# Altium schematic sheet PS_Controller_SCH.SchDoc(PS_Controller_SCH)
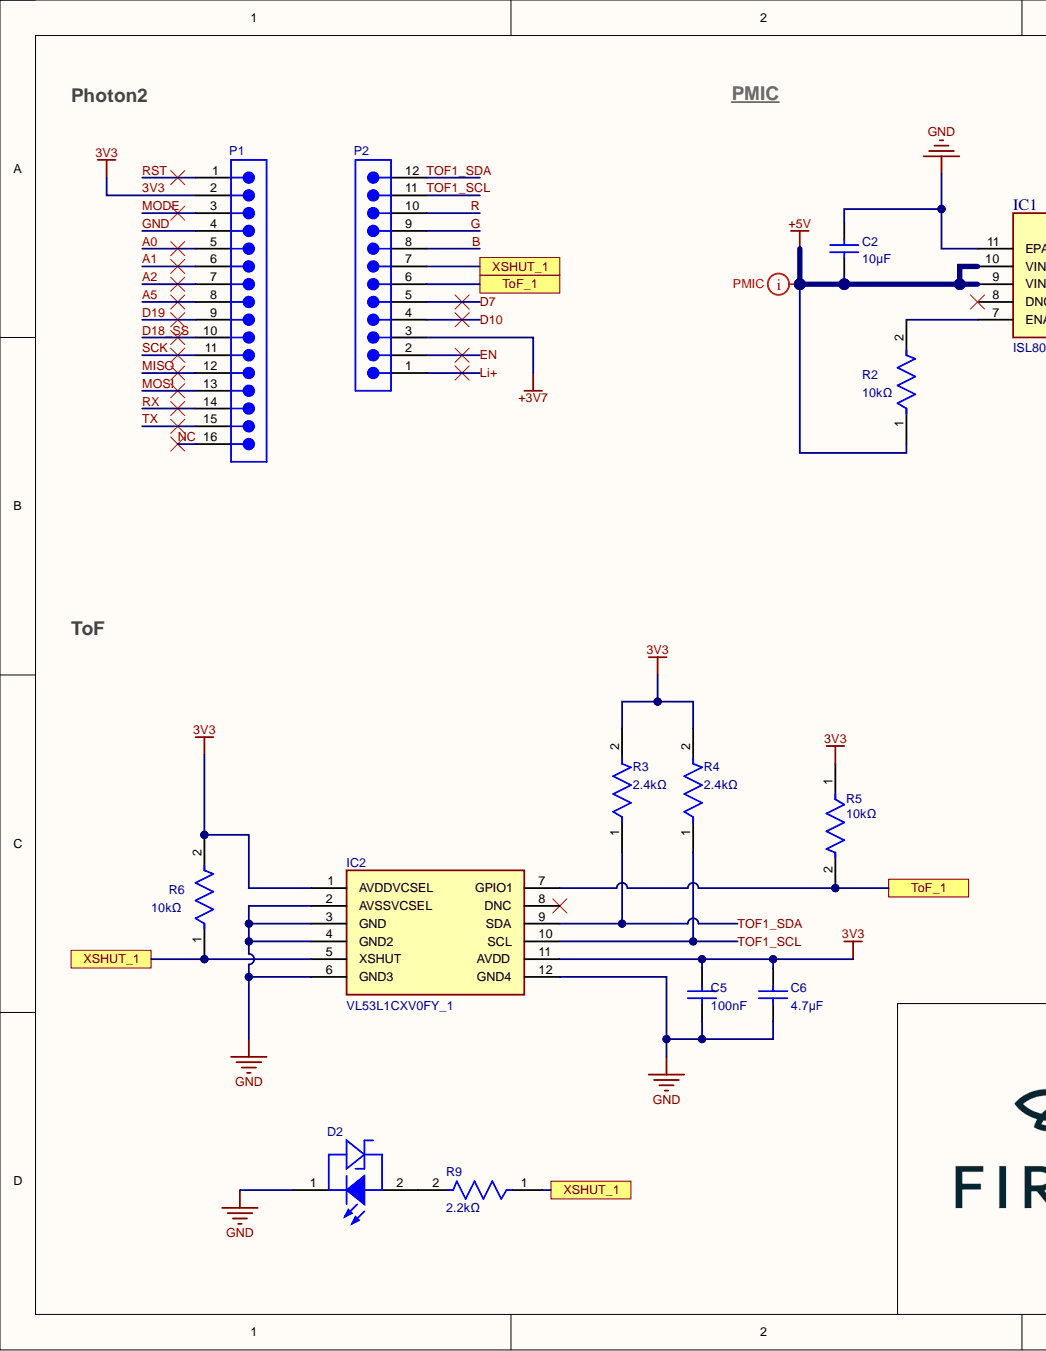
[diagram p0: PS_Controller_SCH.SchDoc, left]
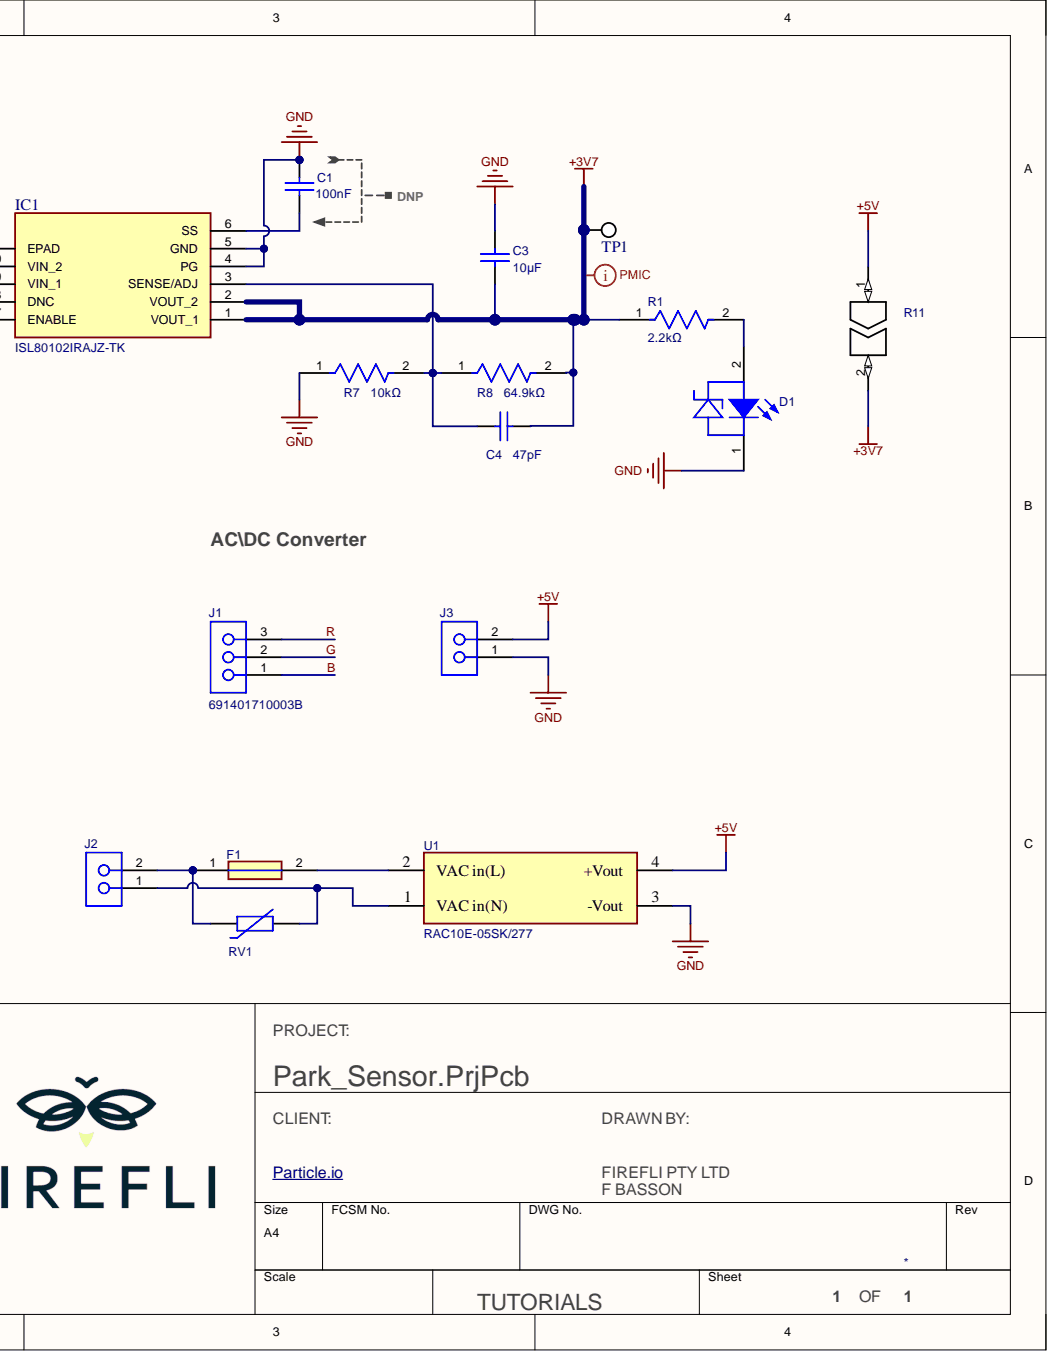
[diagram p1: PS_Controller_SCH.SchDoc, right]

## Parts
C1  Capacitance: 100nF  [WCAP-CSGP_0805_L2W1.25H0.8_Reflow]  (hidden comment: 885012207072)
C2  Capacitance: 10µF  [WCAP-CSGP_0805_L2W1.25H1.25]  (hidden comment: 885012107014)
C3  Capacitance: 10µF  [WCAP-CSGP_0805_L2W1.25H1.25]  (hidden comment: 885012107014)
C4  Capacitance: 47pF  [WCAP-CSGP_0603_L1.6W0.8H0.8_Reflow]  (hidden comment: 885012006021)
C5  Capacitance: 100nF  [WCAP-CSGP_0603_L1.6W0.8H0.8_Reflow]  (hidden comment: 885012206071)
C6  Capacitance: 4.7µF  [WCAP-CSGP_0603_L1.6W0.8H0.8_Reflow]  (hidden comment: 885012106012)
D1  150060BS73220  [WL-SMCC_0603_GS_BS]  (value not drawn)
D2  150060BS73220  [WL-SMCC_0603_GS_BS]  (value not drawn)
F1  SF-3812TM200T-2  [FUSC10131X330N]  (value not drawn)
IC1  ISL80102IRAJZ-TK  [ISL80101IRAJZ]
IC2  VL53L1CXV0FY_1  [VL53L1CXV0FY1]
J1  691401710003B  [691401710003B]
J2  691401710002B  [691401710002B]  (value not drawn)
J3  691401710002B  [691401710002B]  (value not drawn)
P1  61301611121  [61301611121]  (value not drawn)
P2  61301211121  [61301211121]  (value not drawn)
R1  value 2.2kΩ  [FP-RK73H1J-IPC_C]  (hidden comment: RK73H1JTTDD2201F)
R2  value 10kΩ  [FP-RK73H1J-IPC_C]  (hidden comment: RK73H1JTTD1002D)
R3  value 2.4kΩ  [FP-RK73H1J-IPC_C]  (hidden comment: RK73H1JTTD2401D)
R4  value 2.4kΩ  [FP-RK73H1J-IPC_C]  (hidden comment: RK73H1JTTD2401D)
R5  value 10kΩ  [FP-RK73H1J-IPC_C]  (hidden comment: RK73H1JTTD1002D)
R6  value 10kΩ  [FP-RK73H1J-IPC_C]  (hidden comment: RK73H1JTTD1002D)
R7  value 10kΩ  [FP-RK73H1J-IPC_C]  (hidden comment: RK73H1JTTD1002D)
R8  value 64.9kΩ  [FP-RK73H1J-IPC_C]  (hidden comment: RK73H1JTTDD6492F)
R9  value 2.2kΩ  [FP-RK73H1J-IPC_C]  (hidden comment: RK73H1JTTDD2201F)
R11  SolderBride_0.2  [RESC0000X00N]  (value not drawn)
RV1  820412711  [D12.5W6.5H16P1.3]  (value not drawn)
TP1  RCUCTE  [KSTN-5019_V]  (value not drawn)
U1  RAC10E-05SK/277  [FP-RAC10E-K-277-MFG]

## Ports
ToF_1, XSHUT_1

## Nets
+5V: C2.1 IC1.9(VIN_1) IC1.10(VIN_2) J3.2 R2.1 R11.1 U1.4(+Vout)
3V3: C3.1 C4.2 C5.2 C6.2 IC1.1(VOUT_1) IC1.2(VOUT_2) IC2.1(AVDDVCSEL) IC2.11(AVDD) P1.2 P2.3 R1.1 R3.2 R4.2 R5.1 R6.2 R8.2 R11.2 TP1.1
A0: P1.5
A1: P1.6
A2: P1.7
A5: P1.8
B: J1.1 P2.8
D7: P2.5
D10: P2.4
D18_SS: P1.10
D19: P1.9
EN: P2.2
G: J1.2 P2.9
GND: C1.2 C2.2 C3.2 C5.1 C6.1 D1.1 D2.1 IC1.4(PG) IC1.5(GND) IC1.11(EPAD) IC2.2(AVSSVCSEL) IC2.3(GND) IC2.4(GND2) IC2.6(GND3) IC2.12(GND4) J3.1 P1.4 R7.1 U1.3(-Vout)
Li+: P2.1
MISO: P1.12
MODE: P1.3
MOSI: P1.13
NC: P1.16
R: J1.3 P2.10
RST: P1.1
RX: P1.14
SCK: P1.11
TOF1_SCL: IC2.10(SCL) P2.11 R4.1
TOF1_SDA: IC2.9(SDA) P2.12 R3.1
ToF_1: IC2.7(GPIO1) P2.6 R5.2 ; port ToF_1
TX: P1.15
XSHUT_1: IC2.5(XSHUT) P2.7 R6.1 R9.1 ; port XSHUT_1
NetC1_1: C1.1 IC1.6(SS)
NetC4_1: C4.1 IC1.3(SENSE/ADJ) R7.2 R8.1
NetD1_2: D1.2 R1.2
NetD2_2: D2.2 R9.2
NetF1_1: F1.1 J2.2 RV1.1
NetF1_2: F1.2 U1.2(VAC_in(L))
NetIC1_7: IC1.7(ENABLE) R2.2
NetJ2_1: J2.1 RV1.2 U1.1(VAC_in(N))

Not connected: IC1 8(DNC); IC2 8(DNC)

## Library data of the parts
C1 = CMP-046-000743-3: WCAP-CSGP Multilayer Ceramic Chip Capacitor, General Purpose, size 0805, X7R, 100nF, 25VDC; Manufacturer: Wurth Elektronik; Capacitance Tolerance: +/-10%; Case/Size Code: 0805; Dissipation Factor: 3.5%; Insulation Resistance: 5GO; Rated Voltage (DC): 25V; Technical Reference: X7R0805104K025DFCT10000; Category: Multilayer Ceramic Chip Capacitors; Ceramic Type: X7R; Component Kind: Standard; Component Type: Standard; ComponentLink1Description: Website Link; ComponentLink1URL: https://www.we-online.com/redexpert/article/885012207072?ad; ComponentLink2Description: Datasheet Link; ComponentLink2URL: https://www.we-online.com/redexpert/spec/885012207072?ad; Design Item ID: 885012207072; Height: 0.8mm; Length: 2mm; Manufacturer Part Number: 885012207072; Match Code: WCAP-CSGP; Mount: Surface Mount; Operating Temperature Max: 125°C; Operating Temperature Min: -55°C; Packaging: Tape and Reel; Pin Count: 2; Source: WCAP-CSGP.SchLib; Width: 1.25mm; Library Name: Friedl_Basson
C2 C3 = CMP-046-000436-4: WCAP-CSGP Multilayer Ceramic Chip Capacitor, General Purpose, size 0805, X5R, 10µF, 16VDC; Manufacturer: Wurth Elektronik; Capacitance Tolerance: +/-20%; Case/Size Code: 0805; Dissipation Factor: 10%; Insulation Resistance: 0.01GO; Rated Voltage (DC): 16V; Technical Reference: X5R0805106M016DFCT10000; Category: Multilayer Ceramic Chip Capacitors; Ceramic Type: X5R; Component Kind: Standard; Component Type: Standard; ComponentLink1Description: Website Link; ComponentLink1URL: https://www.we-online.com/redexpert/article/885012107014?ad; ComponentLink2Description: Datasheet Link; ComponentLink2URL: https://www.we-online.com/redexpert/spec/885012107014?ad; Design Item ID: 885012107014; Height: 1.25mm; Length: 2mm; Manufacturer Part Number: 885012107014; Match Code: WCAP-CSGP; Mount: Surface Mount; Operating Temperature Max: 85°C; Operating Temperature Min: -55°C; Packaging: Tape and Reel; Pin Count: 2; Source: WCAP-CSGP.SchLib; Width: 1.25mm; Library Name: Friedl_Basson
C4 = CMP-046-000106-4: WCAP-CSGP Multilayer Ceramic Chip Capacitor, General Purpose, size 0603, NP0, 47pF, 16VDC; Manufacturer: Wurth Elektronik; Capacitance Tolerance: +/-5%; Case/Size Code: 0603; Insulation Resistance: 10GO; Q Factor: 1000; Rated Voltage (DC): 16V; Technical Reference: NP00603470J016DFCT10000; Category: Multilayer Ceramic Chip Capacitors; Ceramic Type: NP0; Component Kind: Standard; Component Type: Standard; ComponentLink1Description: Website Link; ComponentLink1URL: https://www.we-online.com/redexpert/article/885012006021?ad; ComponentLink2Description: Datasheet Link; ComponentLink2URL: https://www.we-online.com/redexpert/spec/885012006021?ad; Design Item ID: 885012006021; Height: 0.8mm; Length: 1.6mm; Manufacturer Part Number: 885012006021; Match Code: WCAP-CSGP; Mount: Surface Mount; Operating Temperature Max: 125°C; Operating Temperature Min: -55°C; Packaging: Tape and Reel; Pin Count: 2; Source: WCAP-CSGP.SchLib; Width: 0.8mm; Library Name: Friedl_Basson
C5 = CMP-046-000626-4: WCAP-CSGP Multilayer Ceramic Chip Capacitor, General Purpose, size 0603, X7R, 100nF, 25VDC; Manufacturer: Wurth Elektronik; Capacitance Tolerance: +/-10%; Case/Size Code: 0603; Dissipation Factor: 3.5%; Insulation Resistance: 5GO; Rated Voltage (DC): 25V; Technical Reference: X7R0603104K025DFCT10000; Category: Multilayer Ceramic Chip Capacitors; Ceramic Type: X7R; Component Kind: Standard; Component Type: Standard; ComponentLink1Description: Website Link; ComponentLink1URL: https://www.we-online.com/redexpert/article/885012206071?ad; ComponentLink2Description: Datasheet Link; ComponentLink2URL: https://www.we-online.com/redexpert/spec/885012206071?ad; Design Item ID: 885012206071; Height: 0.8mm; Length: 1.6mm; Manufacturer Part Number: 885012206071; Match Code: WCAP-CSGP; Mount: Surface Mount; Operating Temperature Max: 125°C; Operating Temperature Min: -55°C; Packaging: Tape and Reel; Pin Count: 2; Source: WCAP-CSGP.SchLib; Width: 0.8mm; Library Name: Friedl_Basson
C6 = CMP-046-000409-4: WCAP-CSGP Multilayer Ceramic Chip Capacitor, General Purpose, size 0603, X5R, 4.7µF, 10VDC; Manufacturer: Wurth Elektronik; Capacitance Tolerance: +/-20%; Case/Size Code: 0603; Dissipation Factor: 10%; Insulation Resistance: 0.02GO; Rated Voltage (DC): 10V; Technical Reference: X5R0603475M010DFCT10000; Category: Multilayer Ceramic Chip Capacitors; Ceramic Type: X5R; Component Kind: Standard; Component Type: Standard; ComponentLink1Description: Website Link; ComponentLink1URL: https://www.we-online.com/redexpert/article/885012106012?ad; ComponentLink2Description: Datasheet Link; ComponentLink2URL: https://www.we-online.com/redexpert/spec/885012106012?ad; Design Item ID: 885012106012; Height: 0.8mm; Length: 1.6mm; Manufacturer Part Number: 885012106012; Match Code: WCAP-CSGP; Mount: Surface Mount; Operating Temperature Max: 85°C; Operating Temperature Min: -55°C; Packaging: Tape and Reel; Pin Count: 2; Source: WCAP-CSGP.SchLib; Width: 0.8mm; Library Name: Friedl_Basson
D1 D2 = CMP-042-000014-1: WL-SMCC SMD Mono-color Chip LED Compact, size 0603, Blue, 3.2V, 120deg; Chip Technology: InGaN; Dominant Wavelength: 470nm; Luminous Intensity: 170mcd; Peak Wavelength: 465nm; Component Kind: Standard; Component Type: Standard; Design Item ID: 150060BS73220; Emitting Color: Blue; Forward Voltage: 3.2V; Mounting Technology: SMT; Operating Temperature: -40 to +85degC; Packaging: Tape and Reel; Part Number: 150060BS73220; Pin Count: 2; Published Date: July 2019; Size: 0603; Source: WL-SMCC_0603.SchLib; Viewing Angle: 120deg; Library Name: Friedl_Basson; Publisher: Wurth Elektronik
F1 = SF-3812TM200T-2: Fuse; Manufacturer_Name: Bourns; Arrow Part Number: SF-3812TM200T-2; Arrow Price/Stock: https://www.arrow.com/en/products/sf-3812tm200t-2/bourns; Component Kind: Standard; Datasheet Link: https://www.bourns.com/docs/product-datasheets/sf-3812tm-t.pdf; Height: 3.3mm; Manufacturer_Part_Number: SF-3812TM200T-2; Mouser Part Number: 652-SF-3812TM200T-2; Mouser Price/Stock: https://www.mouser.co.uk/ProductDetail/Bourns/SF-3812TM200T-2?qs=l7cgNqFNU1hBlhxXEWHkxQ%3D%3D
IC1 = ISL80102IRAJZ-TK: Integrated Circuit; Manufacturer_Name: Renesas Electronics; Arrow Part Number: ISL80102IRAJZ-TK; Arrow Price/Stock: https://www.arrow.com/en/products/isl80102irajz-tk/intersil; Component Kind: Standard; Datasheet Link: https://www.renesas.com/en-us/www/doc/datasheet/isl80102-03.pdf; Library Name: SamacSys.SchLib; Manufacturer_Part_Number: ISL80102IRAJZ-TK; Mouser Part Number: 968-ISL80102IRAJZ-TK; Mouser Price/Stock: https://www.mouser.co.uk/ProductDetail/Renesas-Intersil/ISL80102IRAJZ-TK?qs=9fLuogzTs8KrZSs4cePooQ%3D%3D
IC2 = VL53L1CXV0FY_1: Integrated Circuit; Manufacturer_Name: STMicroelectronics; Manufacturer_Part_Number: VL53L1CXV0FY/1; Arrow Part Number: VL53L1CXV0FY/1; Arrow Price/Stock: https://www.arrow.com/en/products/vl53l1cxv0fy1/stmicroelectronics?region=europe; Component Kind: Standard; Datasheet Link: https://componentsearchengine.com/Datasheets/1/VL53L1CXV0FY_1.pdf; Height: 1mm; Mouser Part Number: 511-VL53L1CXV0FY/1; Mouser Price/Stock: https://www.mouser.co.uk/ProductDetail/STMicroelectronics/VL53L1CXV0FY-1?qs=W0yvOO0ixfFQE5v5L6h6PA%3D%3D
J1 = CMP-039-000448-1: WR-TBL Series 401B Horizontal Entry, Through Hole, pitch 5mm, 3p; Manufacturer: Wurth Elektronik; Modularity: No; PCB/Cable/Panel: PCB; Pin Number: 3; Rated Current: 10A; Working Voltage: 300V; Application: Screwless; Category: Terminal Blocks; Component Kind: Standard; Component Type: Standard; ComponentLink1Description: Manufacturer Link; ComponentLink1URL: https://www.we-online.com/redexpert/article/691401710003B?ad; ComponentLink2Description: Datasheet; ComponentLink2URL: https://www.we-online.com/redexpert/spec/691401710003B?ad; Design Item ID: 691401710003B; Manufacturer Part Number: 691401710003B; Match Code: WR-TBL; Mount: Through Hole; Operating Temperature Max: 105°C; Operating Temperature Min: -40°C; Orientation: Horizontal; Packaging: Box; Pin Count: 3; Pitch: 5mm; Source: WR-TBL.SchLib; Library Name: Friedl_Basson
J2 J3 = CMP-039-000447-1: WR-TBL Series 401B Horizontal Entry, Through Hole, pitch 5mm, 2p; Manufacturer: Wurth Elektronik; Modularity: No; PCB/Cable/Panel: PCB; Pin Number: 2; Rated Current: 10A; Working Voltage: 300V; Application: Screwless; Category: Terminal Blocks; Component Kind: Standard; Component Type: Standard; ComponentLink1Description: Manufacturer Link; ComponentLink1URL: https://www.we-online.com/redexpert/article/691401710002B?ad; ComponentLink2Description: Datasheet; ComponentLink2URL: https://www.we-online.com/redexpert/spec/691401710002B?ad; Design Item ID: 691401710002B; Manufacturer Part Number: 691401710002B; Match Code: WR-TBL; Mount: Through Hole; Operating Temperature Max: 105°C; Operating Temperature Min: -40°C; Orientation: Horizontal; Packaging: Box; Pin Count: 2; Pitch: 5mm; Source: WR-TBL.SchLib; Library Name: Friedl_Basson
P1 = CMP-1502-01095-3: NexarPartId: 32855548; Connector Type: Male Pin; Contact Resistance: 20mΩ; Current Rating: 3A; Gender: Male; Number of Contacts: 16; Operating Supply Voltage: 250V; Pitch: 2.54mm; Color: Black; Component Kind: Standard; Contact Material: Copper; Contact Mating Length: 5.9944mm; Contact Plating: Gold; Height: 8.89mm; Lead Pitch: 2.54mm; Library Name: Altium Content Vault; Max Operating Temperature: 105°C; Min Operating Temperature: -40°C; Mount: Through Hole; Number of Pins: 16; Number of Positions: 16; Number of Rows: 1; Orientation: Vertical; Packaging: Bulk; REACH SVHC: Unknown; RoHS: Compliant; Termination: Solder; Voltage Rating: 250V
P2 = CMP-1502-01086-2: NexarPartId: 32855538; Connector Type: Male Pin; Contact Resistance: 20mΩ; Current Rating: 3A; Gender: Male; Number of Contacts: 12; Operating Supply Voltage: 250V; Pitch: 2.54mm; Color: Black; Component Kind: Standard; Contact Material: Copper; Contact Mating Length: 5.9944mm; Contact Plating: Gold; Lead Pitch: 2.54mm; Library Name: Altium Content Vault; Mount: Through Hole; Number of Pins: 12; Number of Positions: 12; Number of Rows: 1; Orientation: Vertical; Packaging: Bulk; REACH SVHC: Unknown; RoHS: Compliant; Termination: Solder
R1 R9 = CMP-009-00269-1: Thick Film Resistors 1/10watt 2.2kΩ 1%; Component Kind: Standard; Library Name: Friedl_Basson
R2 R5-R7 = CMP-009-00265-1: Res Thick Film 0603 10KΩ 0.5% 0.1W(1/10W) ±100ppm/C Pad SMD Automotive T/R; Component Kind: Standard; Library Name: Friedl_Basson
R3 R4 = CMP-009-00270-1: Res Thick Film 0603 2.4kΩ 0.5% 0.1W(1/10W) ±100ppm/C Pad SMD Automotive T/R; Component Kind: Standard; Library Name: Friedl_Basson
R8 = CMP-009-00277-1: Thick Film Resistors - SMD 1/10watts 64.9Ω; Component Kind: Standard; Library Name: Friedl_Basson
R11 = CMP-009-00226-5: OPEN Solder brige 3mm x1.5mm; Component Kind: Standard; Library Name: Friedl_Basson
RV1 = CMP-03913-75574472-1: 820412711 Disk Varistor HighSurge WE-VD; Voltage Rating (AC): 275V; Voltage Rating (DC): 350V; Component Kind: Standard; Diameter: 12.5mm; Height: 16mm; Length: 12.5mm; Library Name: Altium Content Vault; Max Operating Temperature: 85°C; Min Operating Temperature: -40°C; NexarPartId: 75574472; RoHS: Compliant; Width: 4.4mm
TP1 = CMP-009-00014-1: RSmall  PC test point; Component Kind: Standard; Library Name: Friedl_Basson
U1 = CMP-29842-000025-1: 10W AC/DC-CONVERTER 'POWERLINE'; Component Kind: Standard; Library Name: Altium Content Vault
